# Altium schematic sheet main_board.SchDoc
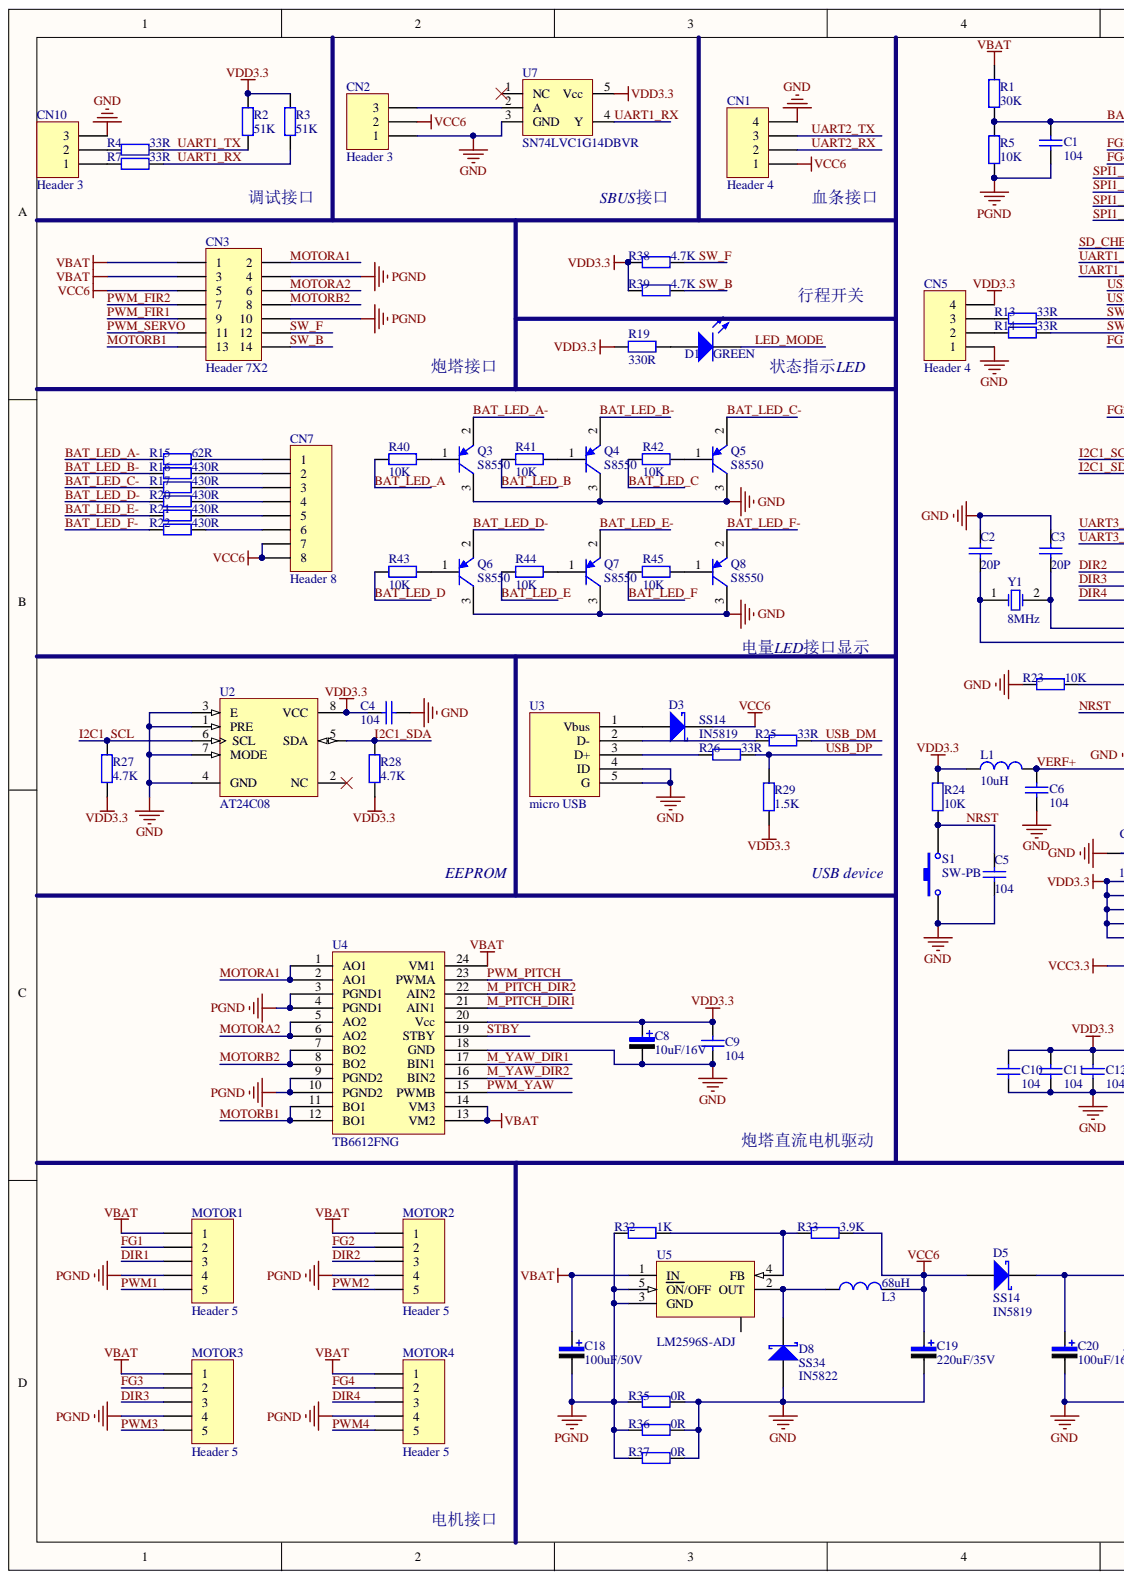
[diagram p0: main_board.SchDoc, left]
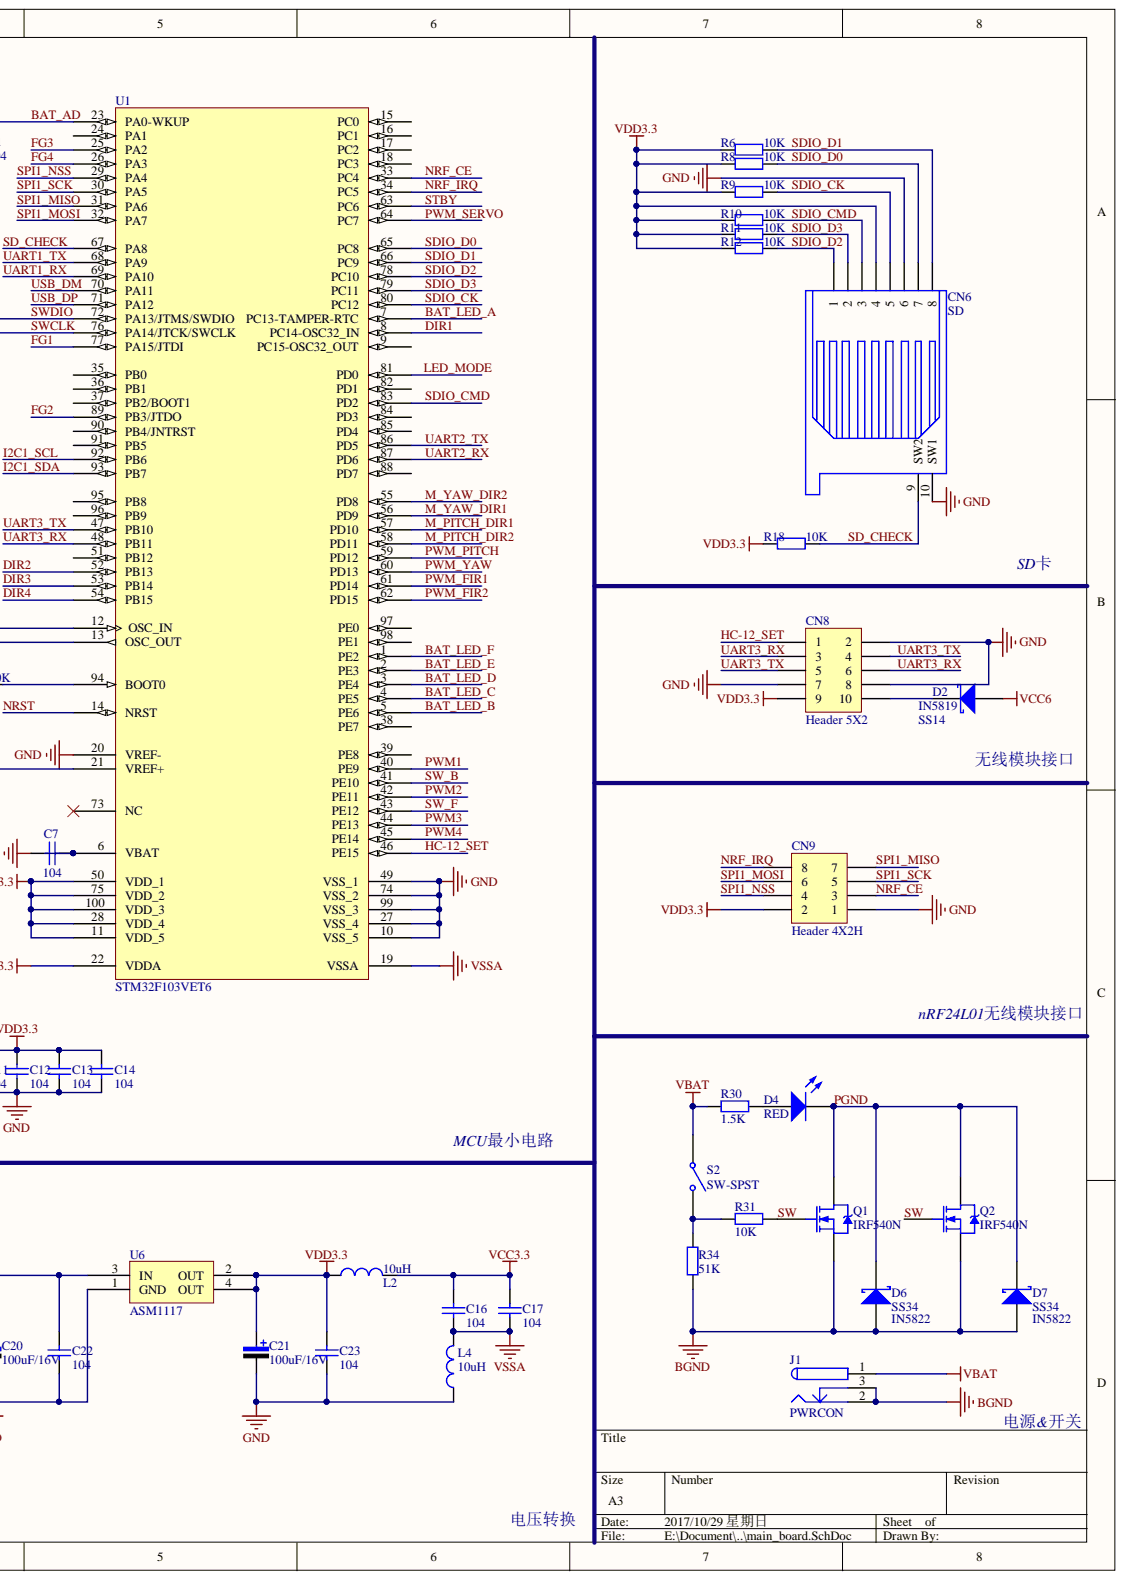
[diagram p1: main_board.SchDoc, right]

## Parts
C1  value 104  [C0603]  (hidden comment: Cap)
C2  value 20P  [C0603]  (hidden comment: Cap)
C3  value 20P  [C0603]  (hidden comment: Cap)
C4  value 104  [C0603]  (hidden comment: Cap)
C5  value 104  [C0603]  (hidden comment: Cap)
C6  value 104  [C0603]  (hidden comment: Cap)
C7  value 104  [C0603]  (hidden comment: Cap)
C8  value 10uF/16V  [CAP-3216]  (hidden comment: Cap-Pol)
C9  value 104  [C0603]  (hidden comment: Cap)
C10  value 104  [C0603]  (hidden comment: Cap)
C11  value 104  [C0603]  (hidden comment: Cap)
C12  value 104  [C0603]  (hidden comment: Cap)
C13  value 104  [C0603]  (hidden comment: Cap)
C14  value 104  [C0603]  (hidden comment: Cap)
C16  value 104  [C0603]  (hidden comment: Cap)
C17  value 104  [C0603]  (hidden comment: Cap)
C18  value 100uF/50V  [C-RB-SD8]  (hidden comment: Cap-Pol-ES)
C19  value 220uF/35V  [C-RB-SD8]  (hidden comment: Cap-Pol-ES)
C20  value 100uF/16V  [CAP-3528]  (hidden comment: Cap-Pol)
C21  value 100uF/16V  [CAP-3528]  (hidden comment: Cap-Pol)
C22  value 104  [C0603]  (hidden comment: Cap)
C23  value 104  [C0603]  (hidden comment: Cap)
CN1  Header 4  [SH1.0-4P-90S]
CN2  Header 3  [HDR3X1-2.54]
CN3  Header 7X2  [DC3-14P-2.0mm-S]
CN5  Header 4  [HDR4X1-2.54]
CN6  SD  [TF]
CN7  Header 8  [FPC0.5-8P-A]
CN8  Header 5X2  [HDR2.54-5Px2-H-90]
CN9  Header 4X2H  [AS01-ML01DP3]
CN10  Header 3  [HDR3X1-2.54]
D1  value GREEN  [LED0603]  (hidden comment: LED0)
D2  IN5819  Type: SS14  [D-SMA]
D3  IN5819  Type: SS14  [D-SMA]
D4  value RED  [LED0603]  (hidden comment: LED0)
D5  IN5819  Type: SS14  [D-SMA]
D6  IN5822  Type: SS34  [D-SMA]
D7  IN5822  Type: SS34  [D-SMA]
D8  IN5822  Type: SS34  [D-SMA]
J1  PWRCON  [DC-005]
L1  value 10uH  [0603-1]  (hidden comment: Inductor)
L2  value 10uH  [0603-1]  (hidden comment: Inductor)
L3  value 68uH  [CDRH127]  (hidden comment: Inductor)
L4  value 10uH  [0603-1]  (hidden comment: Inductor)
MOTOR1  Header 5  [SH1.0-5P-90S]
MOTOR2  Header 5  [SH1.0-5P-90S]
MOTOR3  Header 5  [SH1.0-5P-90S]
MOTOR4  Header 5  [SH1.0-5P-90S]
Q1  IRF540N  [TO263-2]
Q2  IRF540N  [TO263-2]
Q3  S8550  [SOT23-3]
Q4  S8550  [SOT23-3]
Q5  S8550  [SOT23-3]
Q6  S8550  [SOT23-3]
Q7  S8550  [SOT23-3]
Q8  S8550  [SOT23-3]
R1  value 30K  [0603-1]  (hidden comment: Res1)
R2  value 51K  [0603-1]  (hidden comment: Res1)
R3  value 51K  [0603-1]  (hidden comment: Res1)
R4  value 33R  [0603-1]  (hidden comment: Res1)
R5  value 10K  [0603-1]  (hidden comment: Res1)
R6  value 10K  [0603-1]  (hidden comment: Res1)
R7  value 33R  [0603-1]  (hidden comment: Res1)
R8  value 10K  [0603-1]  (hidden comment: Res1)
R9  value 10K  [0603-1]  (hidden comment: Res1)
R10  value 10K  [0603-1]  (hidden comment: Res1)
R11  value 10K  [0603-1]  (hidden comment: Res1)
R12  value 10K  [0603-1]  (hidden comment: Res1)
R13  value 33R  [0603-1]  (hidden comment: Res1)
R14  value 33R  [0603-1]  (hidden comment: Res1)
R15  value 62R  [0603-1]  (hidden comment: Res1)
R16  value 430R  [0603-1]  (hidden comment: Res1)
R17  value 430R  [0603-1]  (hidden comment: Res1)
R18  value 10K  [0603-1]  (hidden comment: Res1)
R19  value 330R  [0603-1]  (hidden comment: Res1)
R20  value 430R  [0603-1]  (hidden comment: Res1)
R21  value 430R  [0603-1]  (hidden comment: Res1)
R22  value 430R  [0603-1]  (hidden comment: Res1)
R23  value 10K  [0603-1]  (hidden comment: Res1)
R24  value 10K  [0603-1]  (hidden comment: Res1)
R25  value 33R  [0603-1]  (hidden comment: Res1)
R26  value 33R  [0603-1]  (hidden comment: Res1)
R27  value 4.7K  [0603-1]  (hidden comment: Res1)
R28  value 4.7K  [0603-1]  (hidden comment: Res1)
R29  value 1.5K  [0603-1]  (hidden comment: Res1)
R30  value 1.5K  [0603-1]  (hidden comment: Res1)
R31  value 10K  [0603-1]  (hidden comment: Res1)
R32  value 1K  [0603-1]  (hidden comment: Res1)
R33  value 3.9K  [0603-1]  (hidden comment: Res1)
R34  value 51K  [0603-1]  (hidden comment: Res1)
R35  value 0R  [0603-1]  (hidden comment: Res1)
R36  value 0R  [0603-1]  (hidden comment: Res1)
R37  value 0R  [0603-1]  (hidden comment: Res1)
R38  value 4.7K  [0603-1]  (hidden comment: Res1)
R39  value 4.7K  [0603-1]  (hidden comment: Res1)
R40  value 10K  [0603-1]  (hidden comment: Res1)
R41  value 10K  [0603-1]  (hidden comment: Res1)
R42  value 10K  [0603-1]  (hidden comment: Res1)
R43  value 10K  [0603-1]  (hidden comment: Res1)
R44  value 10K  [0603-1]  (hidden comment: Res1)
R45  value 10K  [0603-1]  (hidden comment: Res1)
S1  SW-PB  [SW_PB_2P_90S]
S2  SW-SPST  [SH1.0-2P-90S]
U1  STM32F103VET6  [LQFP100_N]
U2  AT24C08  [SOP8_N]
U3  micro USB  [USB_MICRO]
U4  TB6612FNG
U5  LM2596S-ADJ  [TO263-5]
U6  ASM1117  [SOT223]
U7  SN74LVC1G14DBVR  [SOT23-5]
Y1  8MHz  [5032]

## Nets
BGND: D6.1 D7.1 J1.2 J1.3 Q1.3 Q2.3 R34.2
GND: C2.2 C3.2 C4.1 C5.1 C6.2 C7.2 C8.2 C9.1 C10.1 C11.1 C12.1 C13.1 C14.1 C19.2 C20.2 C21.2 C22.1 C23.1 CN1.4 CN2.1 CN5.1 CN6.6 CN6.10(SW1) CN8.2 CN8.7 CN8.8 CN9.1 CN10.3 D8.1 L4.1 Q3.3 Q4.3 Q5.3 Q6.3 Q7.3 Q8.3 R23.1 R35.2 R36.2 R37.2 S1.1 U1.10(VSS_5) U1.20(VREF-) U1.27(VSS_4) U1.49(VSS_1) U1.74(VSS_2) U1.99(VSS_3) U2.1(PRE) U2.3(E) U2.4(GND) U2.7(MODE) U3.4(ID) U3.5(G) U4.18(GND) U6.1(GND) U7.3(GND)
VBAT: C18.1 CN3.1 CN3.3 J1.1 MOTOR1.1 MOTOR2.1 MOTOR3.1 MOTOR4.1 R1.2 R30.2 S2.1 U4.13(VM2) U4.14(VM3) U4.24(VM1) U5.1(IN)
VCC3.3: C16.2 C17.2 L2.2 U1.22(VDDA)
VCC6: C19.1 CN1.1 CN2.2 CN3.5 CN7.7 CN7.8 D2.1 D3.2 D5.1 L3.2 R33.2
VDD3.3: C4.2 C8.1 C9.2 C10.2 C11.2 C12.2 C13.2 C14.2 C21.1 C23.2 CN5.4 CN6.4 CN8.9 CN9.2 L1.1 L2.1 R2.2 R3.2 R6.2 R8.2 R9.2 R10.2 R11.2 R12.2 R18.2 R19.2 R24.2 R27.2 R28.1 R29.2 R38.2 R39.2 U1.11(VDD_5) U1.28(VDD_4) U1.50(VDD_1) U1.75(VDD_2) U1.100(VDD_3) U2.8(VCC) U4.20(Vcc) U6.2(OUT) U6.4(OUT) U7.5(Vcc)
VSSA: C16.1 C17.1 L4.2 U1.19(VSSA)
BAT_AD: C1.2 R1.1 R5.2 U1.23(PA0-WKUP)
BAT_LED_A: R40.2 U1.7(PC13-TAMPER-RTC)
BAT_LED_A-: Q3.2 R15.2
BAT_LED_B: R41.2 U1.5(PE6)
BAT_LED_B-: Q4.2 R16.2
BAT_LED_C: R42.2 U1.4(PE5)
BAT_LED_C-: Q5.2 R17.2
BAT_LED_D: R43.2 U1.3(PE4)
BAT_LED_D-: Q6.2 R20.2
BAT_LED_E: R44.2 U1.2(PE3)
BAT_LED_E-: Q7.2 R21.2
BAT_LED_F: R45.2 U1.1(PE2)
BAT_LED_F-: Q8.2 R22.2
DIR1: MOTOR1.3 U1.8(PC14-OSC32_IN)
DIR2: MOTOR2.3 U1.52(PB13)
DIR3: MOTOR3.3 U1.53(PB14)
DIR4: MOTOR4.3 U1.54(PB15)
FG1: MOTOR1.2 U1.77(PA15/JTDI)
FG2: MOTOR2.2 U1.89(PB3/JTDO)
FG3: MOTOR3.2 U1.25(PA2)
FG4: MOTOR4.2 U1.26(PA3)
HC-12_SET: CN8.1 U1.46(PE15)
I2C1_SCL: R27.1 U1.92(PB6) U2.6(SCL)
I2C1_SDA: R28.2 U1.93(PB7) U2.5(SDA)
LED_MODE: D1.2 U1.81(PD0)
M_PITCH_DIR1: U1.57(PD10) U4.21(AIN1)
M_PITCH_DIR2: U1.58(PD11) U4.22(AIN2)
M_YAW_DIR1: U1.56(PD9) U4.17(BIN1)
M_YAW_DIR2: U1.55(PD8) U4.16(BIN2)
MOTORA1: CN3.2 U4.1(AO1) U4.2(AO1)
MOTORA2: CN3.6 U4.5(AO2) U4.6(AO2)
MOTORB1: CN3.13 U4.11(BO1) U4.12(BO1)
MOTORB2: CN3.8 U4.7(BO2) U4.8(BO2)
NRF_CE: CN9.3 U1.33(PC4)
NRF_IRQ: CN9.8 U1.34(PC5)
NRST: C5.2 R24.1 S1.2 U1.14(NRST)
PGND: C1.1 C18.2 CN3.4 CN3.10 D4.2 D6.2 D7.2 MOTOR1.4 MOTOR2.4 MOTOR3.4 MOTOR4.4 Q1.2 Q2.2 R5.1 R32.1 R35.1 R36.1 R37.1 U4.3(PGND1) U4.4(PGND1) U4.9(PGND2) U4.10(PGND2) U5.3(GND) U5.5(ON/OFF)
PWM1: MOTOR1.5 U1.40(PE9)
PWM2: MOTOR2.5 U1.42(PE11)
PWM3: MOTOR3.5 U1.44(PE13)
PWM4: MOTOR4.5 U1.45(PE14)
PWM_FIR1: CN3.9 U1.61(PD14)
PWM_FIR2: CN3.7 U1.62(PD15)
PWM_PITCH: U1.59(PD12) U4.23(PWMA)
PWM_SERVO: CN3.11 U1.64(PC7)
PWM_YAW: U1.60(PD13) U4.15(PWMB)
SD_CHECK: CN6.9(SW2) R18.1 U1.67(PA8)
SDIO_CK: CN6.5 R9.1 U1.80(PC12)
SDIO_CMD: CN6.3 R10.1 U1.83(PD2)
SDIO_D0: CN6.7 R8.1 U1.65(PC8)
SDIO_D1: CN6.8 R6.1 U1.66(PC9)
SDIO_D2: CN6.1 R12.1 U1.78(PC10)
SDIO_D3: CN6.2 R11.1 U1.79(PC11)
SPI1_MISO: CN9.7 U1.31(PA6)
SPI1_MOSI: CN9.6 U1.32(PA7)
SPI1_NSS: CN9.4 U1.29(PA4)
SPI1_SCK: CN9.5 U1.30(PA5)
STBY: U1.63(PC6) U4.19(STBY)
SW: Q1.1 Q2.1 R31.2
SW_B: CN3.14 R39.1 U1.41(PE10)
SW_F: CN3.12 R38.1 U1.43(PE12)
SWCLK: R14.1 U1.76(PA14/JTCK/SWCLK)
SWDIO: R13.1 U1.72(PA13/JTMS/SWDIO)
UART1_RX: R3.1 R7.1 U1.69(PA10) U7.4(Y)
UART1_TX: R2.1 R4.1 U1.68(PA9)
UART2_RX: CN1.2 U1.87(PD6)
UART2_TX: CN1.3 U1.86(PD5)
UART3_RX: CN8.3 CN8.6 U1.48(PB11)
UART3_TX: CN8.4 CN8.5 U1.47(PB10)
USB_DM: R25.1 U1.70(PA11)
USB_DP: R26.1 R29.1 U1.71(PA12)
VERF+: C6.1 L1.2 U1.21(VREF+)
NetC2_1: C2.1 U1.13(OSC_OUT) Y1.1
NetC3_1: C3.1 U1.12(OSC_IN) Y1.2
NetC7_1: C7.1 U1.6(VBAT)
NetC20_1: C20.1 C22.2 D5.2 U6.3(IN)
NetCN2_3: CN2.3 U7.2(A)
NetCN5_2: CN5.2 R14.2
NetCN5_3: CN5.3 R13.2
NetCN7_1: CN7.1 R15.1
NetCN7_2: CN7.2 R16.1
NetCN7_3: CN7.3 R17.1
NetCN7_4: CN7.4 R20.1
NetCN7_5: CN7.5 R21.1
NetCN7_6: CN7.6 R22.1
NetCN8_10: CN8.10 D2.2
NetCN10_1: CN10.1 R7.2
NetCN10_2: CN10.2 R4.2
NetD1_1: D1.1 R19.1
NetD3_1: D3.1 U3.1(Vbus)
NetD4_1: D4.1 R30.1
NetD8_2: D8.2 L3.1 U5.2(OUT)
NetQ3_1: Q3.1 R40.1
NetQ4_1: Q4.1 R41.1
NetQ5_1: Q5.1 R42.1
NetQ6_1: Q6.1 R43.1
NetQ7_1: Q7.1 R44.1
NetQ8_1: Q8.1 R45.1
NetR23_2: R23.2 U1.94(BOOT0)
NetR25_2: R25.2 U3.2(D-)
NetR26_2: R26.2 U3.3(D+)
NetR31_1: R31.1 R34.1 S2.2
NetR32_2: R32.2 R33.1 U5.4(FB)

Not connected: U1 9(PC15-OSC32_OUT) 15(PC0) 16(PC1) 17(PC2) 18(PC3) 24(PA1) 35(PB0) 36(PB1) 37(PB2/BOOT1) 38(PE7) 39(PE8) 51(PB12) 73(NC) 82(PD1) 84(PD3) 85(PD4) 88(PD7) 90(PB4/JNTRST) 91(PB5) 95(PB8) 96(PB9) 97(PE0) 98(PE1); U2 2(NC); U5 6; U7 1(NC)

## Library data of the parts
C1-C7 C9-C14 C16 C17 C22 C23 = Cap: Capacitor; Component Kind: Standard; LatestRevisionDate: 17-Jul-2002; LatestRevisionNote: Re-released for DXP Platform.; Published: 8-Jun-2000; Library Name: STM32F103VET6_VCT6mini最小系统-原理图库.SCHLIB; Publisher: Altium Limited
C8 C20 C21 = Cap-Pol-A: 钽电容; Component Kind: Standard; LatestRevisionDate: 17-Jul-2002; LatestRevisionNote: Re-released for DXP Platform.; PackageReference: RB7.6-15; Published: 8-Jun-2000; Library Name: Fszhang.IntLib; Publisher: Altium Limited
C18 C19 = Cap-Pol-ES: 贴片铝电解电容; Component Kind: Standard; LatestRevisionDate: 17-Jul-2002; LatestRevisionNote: Re-released for DXP Platform.; PackageReference: RB7.6-15; Published: 8-Jun-2000; Library Name: Fszhang.IntLib; Publisher: Altium Limited
CN1 CN5 = Header 4: Header, 4-Pin; Component Kind: Standard; LatestRevisionDate: 17-Jul-2002; LatestRevisionNote: Re-released for DXP Platform.; Library Name: Miscellaneous Connectors.IntLib; Publisher: Altium Limited
CN2 CN10 = Header 3: Header, 3-Pin; Component Kind: Standard; LatestRevisionDate: 17-Jul-2002; LatestRevisionNote: Re-released for DXP Platform.; Library Name: Miscellaneous Connectors.IntLib; Publisher: Altium Limited
CN3 = Header 7X2: Header, 7-Pin, Dual row; Component Kind: Standard; LatestRevisionDate: 17-Jul-2002; LatestRevisionNote: Re-released for DXP Platform.; Library Name: Miscellaneous Connectors.IntLib; Publisher: Altium Limited
CN6 = SMS016AFA5E: Component Kind: Standard; Library Name: STM32F103VET6_VCT6mini最小系统-原理图库.SCHLIB
CN7 = Header 8: Header, 8-Pin; Component Kind: Standard; LatestRevisionDate: 17-Jul-2002; LatestRevisionNote: Re-released for DXP Platform.; Library Name: Miscellaneous Connectors.IntLib; Publisher: Altium Limited
CN8 = Header 5X2: Header, 5-Pin, Dual row; Component Kind: Standard; LatestRevisionDate: 17-Jul-2002; LatestRevisionNote: Re-released for DXP Platform.; Library Name: Miscellaneous Connectors.IntLib; Publisher: Altium Limited
CN9 = Header 4X2H: Header, 4-Pin, Dual row, Right Angle; Component Kind: Standard; LatestRevisionDate: 17-Jul-2002; LatestRevisionNote: Re-released for DXP Platform.; Library Name: Miscellaneous Connectors.IntLib; Publisher: Altium Limited
D1 D4 = LED0: 发光二极管; Component Kind: Standard; LatestRevisionDate: 08-Jul-2005; LatestRevisionNote: Mech Layer 1 removed.; PackageReference: LED-0; Published: 8-Jun-2000; Library Name: Fszhang.IntLib; Publisher: Altium Limited
D2 D3 D5-D8 = Diode-Schottky: 肖特基二极管; Code_JEDEC: DO-214-AA; Component Kind: Standard; LatestRevisionDate: 17-Jul-2002; LatestRevisionNote: Re-released for DXP Platform.; PackageReference: SMB; Published: 8-Jun-2000; Library Name: Fszhang.IntLib; Publisher: Altium Limited
J1 = PWRCON: 直流电源端子; Component Kind: Standard; ComponentLink1Description: Manufacturer Link; ComponentLink1URL: http://domino.kycon.com/internet/Products.html; DatasheetDocument: 09-May-2002; Published: 17-Dec-2003; Library Name: HDR_Fszhang.IntLib; Publisher: Altium Limited
L1-L4 = Inductor: 电感; Code_IEC: 1005; Code_JEITA: 0402; Component Kind: Standard; LatestRevisionDate: 17-Jul-2002; LatestRevisionNote: Re-released for DXP Platform.; PackageReference: 0402-A; Published: 8-Jun-2000; Library Name: Fszhang.IntLib; Publisher: Altium Limited
MOTOR1-MOTOR4 = Header 5: Header, 5-Pin; Component Kind: Standard; LatestRevisionDate: 17-Jul-2002; LatestRevisionNote: Re-released for DXP Platform.; Library Name: Miscellaneous Connectors.IntLib; Publisher: Altium Limited
Q1 Q2 = NMOS-2: N-Channel Power MOSFET; Note: Pin 2 is electrically connected to pin 4.; Component Kind: Standard; ComponentLink1Description: Manufacturer Link; ComponentLink1URL: http://www.irf.com/; DatasheetVersion: PD-9.814; LatestRevisionDate: 17-Jul-2002; LatestRevisionNote: Re-released for DXP Platform.; Library Name: Miscellaneous Devices.IntLib; PackageDescription: TO, Thru-Hole, Vertical, Heatsink Mounted; 3 In-Line Leads; Pitch 2.54 mm; PackageReference: TO-220AB; PackageVersion: Feb-1997; Published: 8-Jun-2000; Simulation: IRF1010; Publisher: Altium Limited
Q3-Q8 = PNP1: 贴片三极管; Code_JEDEC: TO-92A; Component Kind: Standard; ComponentLink1Description: Manufacturer Link; ComponentLink1URL: http://www.fairchildsemi.com/; ComponentLink2Description: Datasheet; ComponentLink2URL: http://www.fairchildsemi.com/ds/2N%2F2N3906.pdf; DatasheetDocument: 1997; LatestRevisionDate: 15-Jan-2003; LatestRevisionNote: PCB Footprint 'BCY-W3/D4.7' replaced; PackageDocument: Sep-1998; PackageReference: TO-92A; Published: 8-Jun-2000; Library Name: Fszhang.IntLib; Publisher: Altium Limited
R1-R45 = Res1: 贴片电阻; Component Kind: Standard; LatestRevisionDate: 17-Jul-2002; LatestRevisionNote: Re-released for DXP Platform.; PackageReference: AXIAL-0.4; Published: 8-Jun-2000; Library Name: Fszhang.IntLib; Publisher: Altium Limited
S1 = SW-PB: 微动开关; Component Kind: Standard; LatestRevisionDate: 17-Jul-2002; LatestRevisionNote: Re-released for DXP Platform.; PackageReference: SPST-2; Published: 24-Mar-1999; Library Name: Fszhang.IntLib; Publisher: Altium Limited
S2 = SW-SPST: Single-Pole, Single-Throw Switch; Component Kind: Standard; LatestRevisionDate: 17-Jul-2002; LatestRevisionNote: Re-released for DXP Platform.; Library Name: Miscellaneous Devices.IntLib; PackageDescription: Switch; 2 Leads; PackageReference: SPST-2; Published: 24-Mar-1999; Publisher: Altium Limited
U1 = STM32F103VBT6: STM32 ARM-based 32-bit MCU with 512 Kbytes Flash, 100-pin LQFP, Industrial Temperature; Component Kind: Standard; ComponentLink1Description: Manufacturer Link; ComponentLink1URL: www.st.com/stm32; ComponentLink2Description: Datasheet; ComponentLink2URL: http://www.st.com/stonline/products/literature/ds/13587.pdf; DatasheetVersion: rev6, Mar-2008; Library Name: ST Microcontroller 32-Bit STM32.IntLib; PackageDescription: 100-Pin Low-Profile Quad Flat Pack, 14 x 14 mm Body, 0.5 mm Pitch (LQFP100); PackageReference: LQFP100; PackageVersion: Mar-2008; Published: 30-May-2008; Publisher: Altium Limited
U2 = ST24C08RB5: Serial 8K (1K x 8) EEPROM; Component Kind: Standard; ComponentLink1Description: Manufacturer Link; ComponentLink1URL: http://www.st.com/; DatasheetVersion: Jul-1996; LatestRevisionDate: 06-Jun-2005; LatestRevisionNote: Stylized 3D Model Added.; PackageDescription: DIP; 8 Leads; Row Spacing 7.62 mm; Pitch 2.54 mm; PackageReference: PSDIP8A; PackageVersion: Jun-1998; Published: 24-Aug-2001; Library Name: STM32F103VET6_VCT6mini最小系统-原理图库.SCHLIB; Publisher: Altium Limited
U3 = usb-STM: Component Kind: Standard; Library Name: STM32F103VET6_VCT6mini最小系统-原理图库.SCHLIB
U5 = LM2596S-ADJ: 可调稳压芯片; Note: Tab is connected to GND; Component Kind: Standard; ComponentLink1Description: Manufacturer Link; ComponentLink1URL: http://www.national.com/; ComponentLink2Description: Datasheet; ComponentLink2URL: http://www.national.com/ds.cgi/LM/LM2596.pdf; ComponentLink3Description: URL Link1; ComponentLink3URL: http://www.national.com/pf/LM/LM2596.html; DatasheetVersion: Dec-1997; PackageDescription: D2-PAK; 5 Leads; Body 14.4 (inc. leads) x 10.4 mm (LxW max); PackageReference: TS5B; Published: 15-Apr-2002; Library Name: Fszhang.IntLib; Publisher: Altium Limited
U6 = ASM1117: 3.3V稳压芯片; Component Kind: Standard; Library Name: Fszhang.IntLib
Y1 = XTAL: Crystal Oscillator; Component Kind: Standard; LatestRevisionDate: 17-Jul-2002; LatestRevisionNote: Re-released for DXP Platform.; Published: 8-Jun-2000; Library Name: STM32F103VET6_VCT6mini最小系统-原理图库.SCHLIB; Publisher: Altium Limited
U4 = TB6612FNG: Component Kind: Standard; Description: TB6612FNG; Library Name: mylib.SchLib
U7 = SN74LVC1G14DBVR: Component Kind: Standard; Library Name: mySCH.SchLib
